# Altium schematic sheet ADC.SchDoc
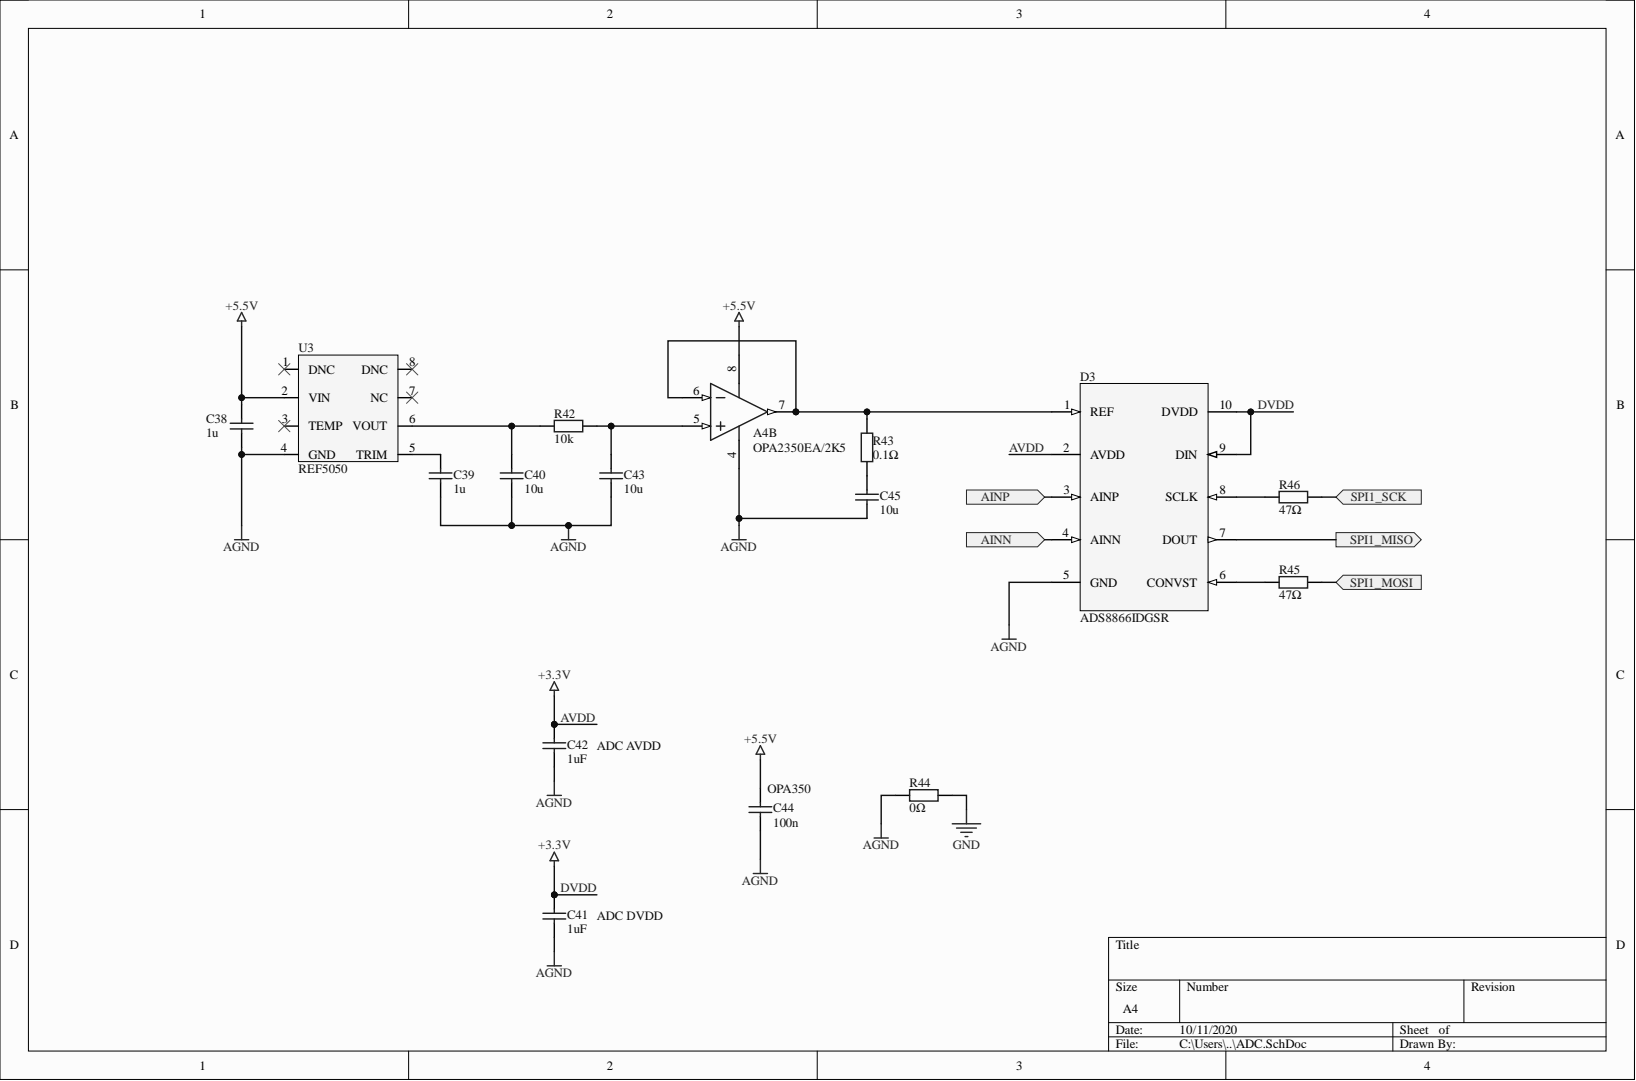
[diagram p0: ADC.SchDoc]

## Parts
A4  OPA2350EA/2K5  [SOIC127P600X175-8N]  (part B)
C38  1u  [CAPC1608X87N]
C39  1u  [CAPC1608X87N]
C40  10u  [CAPC2012X110N]
C41  1uF  [CAPC1608X87N]
C42  1uF  [CAPC1608X87N]
C43  10u  [CAPC2012X110N]
C44  100n  [CAPC1608X87N]
C45  10u  [CAPC2012X110N]
D3  ADS8866IDGSR  [SOP50P490X110-10N]
R42  10k  [RESC1608X55N]
R43  0.1Ω  [RESC1608X55N]
R44  0Ω  [RESC1608X55N]
R45  47Ω  [RESC1608X55N]
R46  47Ω  [RESC1608X55N]
U3  REF5050  [SOIC127P600X175-8N]

## Ports
AINN, AINP, SPI1_MISO, SPI1_MOSI, SPI1_SCK

## Nets
+5.5V: A4.8 C38.2 C44.2 U3.2(VIN)
AGND: A4.4 C38.1 C39.1 C40.1 C41.1 C42.1 C43.1 C44.1 C45.2 D3.5(GND) R44.1 U3.4(GND)
GND: R44.2
AINN: D3.4(AINN) ; port AINN
AINP: D3.3(AINP) ; port AINP
AVDD: C41.2 C42.2 D3.2(AVDD) D3.9(DIN) D3.10(DVDD)
SPI1_MISO: D3.7(DOUT) ; port SPI1_MISO
SPI1_MOSI: R45.2 ; port SPI1_MOSI
SPI1_SCK: R46.2 ; port SPI1_SCK
NetA4_5: A4.5 C43.2 R42.2
NetA4_6: A4.6 A4.7 D3.1(REF) R43.2
NetC39_2: C39.2 U3.5(TRIM)
NetC40_2: C40.2 R42.1 U3.6(VOUT)
NetC45_1: C45.1 R43.1
NetD3_6: D3.6(CONVST) R45.1
NetD3_8: D3.8(SCLK) R46.1

Not connected: U3 1(DNC) 3(TEMP) 7(NC) 8(DNC)

## Library data of the parts
A4 = OPA2350EA/250: Manufacturer 1: Texas Instruments; Component Kind: Standard; Library Name: TI Amplifiers and Linear Operational Amplifier Precision Amplifier Part One.IntLib; Manufacturer Part Number 1: OPA2350EA/2K5
C38 C39 C41 C42 = Cap: Manufacturer 1: Taiyo Yuden; Manufacturer Part Number 1: EMK107BJ105KA-T; Component Kind: Standard; Library Name: Miscellaneous Devices.IntLib; Signal Integrity: Cap; Simulation: CAP
C40 C43 C45 = Cap: Manufacturer 1: Taiyo Yuden; Manufacturer Part Number 1: EMK212BB7106KG-T; Component Kind: Standard; Library Name: Miscellaneous Devices.IntLib; Signal Integrity: Cap; Simulation: CAP
C44 = Cap: Manufacturer 1: Taiyo Yuden; Manufacturer Part Number 1: EMK107B7104KA-T; Component Kind: Standard; Library Name: Miscellaneous Devices.IntLib; Signal Integrity: Cap; Simulation: CAP
D3 = AD8866: Manufacturer 1: Texas Instruments; Component Kind: Standard; Library Name: Custom_Lib.SchLib; Manufacturer Part Number 1: ADS8866IDGSR
R42 = Res2: Manufacturer 1: Yageo; Manufacturer Part Number 1: RC0603FR-0710KL; Component Kind: Standard; Library Name: Miscellaneous Devices.IntLib; MOUSER Part Number: 603-RC0603FR-0710KL; Signal Integrity: Res; Simulation: RESISTOR
R43 = Res2: Manufacturer 1: Wurth Elektronik; Manufacturer Part Number 1: 560050316001; Component Kind: Standard; Library Name: Miscellaneous Devices.IntLib; Signal Integrity: Res; Simulation: RESISTOR
R44 = Res2: Manufacturer 1: Panasonic; Manufacturer Part Number 1: ERJ-3GEY0R00V; Component Kind: Standard; Library Name: Miscellaneous Devices.IntLib; Signal Integrity: Res; Simulation: RESISTOR
R45 R46 = Res2: Manufacturer 1: Vishay/Dale; Manufacturer Part Number 1: CRCW060347R0JNEAC; Component Kind: Standard; Library Name: Miscellaneous Devices.IntLib; Signal Integrity: Res; Simulation: RESISTOR
U3 = REF5050: Manufacturer 1: Texas Instruments; Manufacturer Part Number 1: REF5050AIDR; Component Kind: Standard; Library Name: Custom_Lib.SchLib
